# Altium schematic sheet HDMI_CONN_POWER_IN.SchDoc
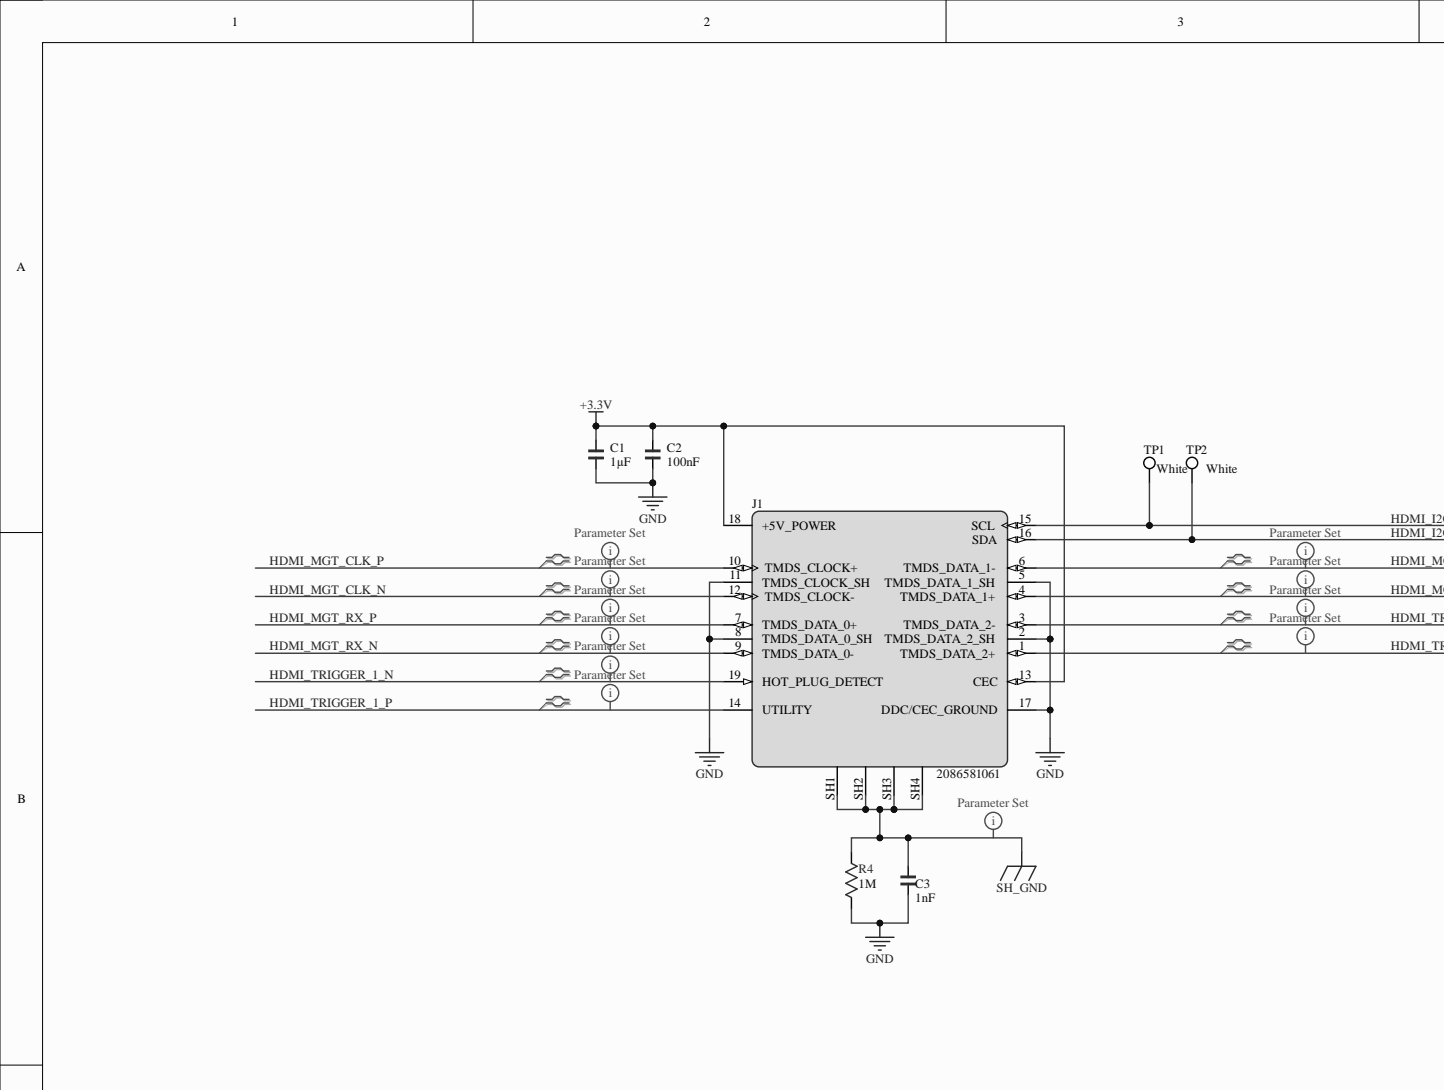
[diagram p0: HDMI_CONN_POWER_IN.SchDoc, top left]
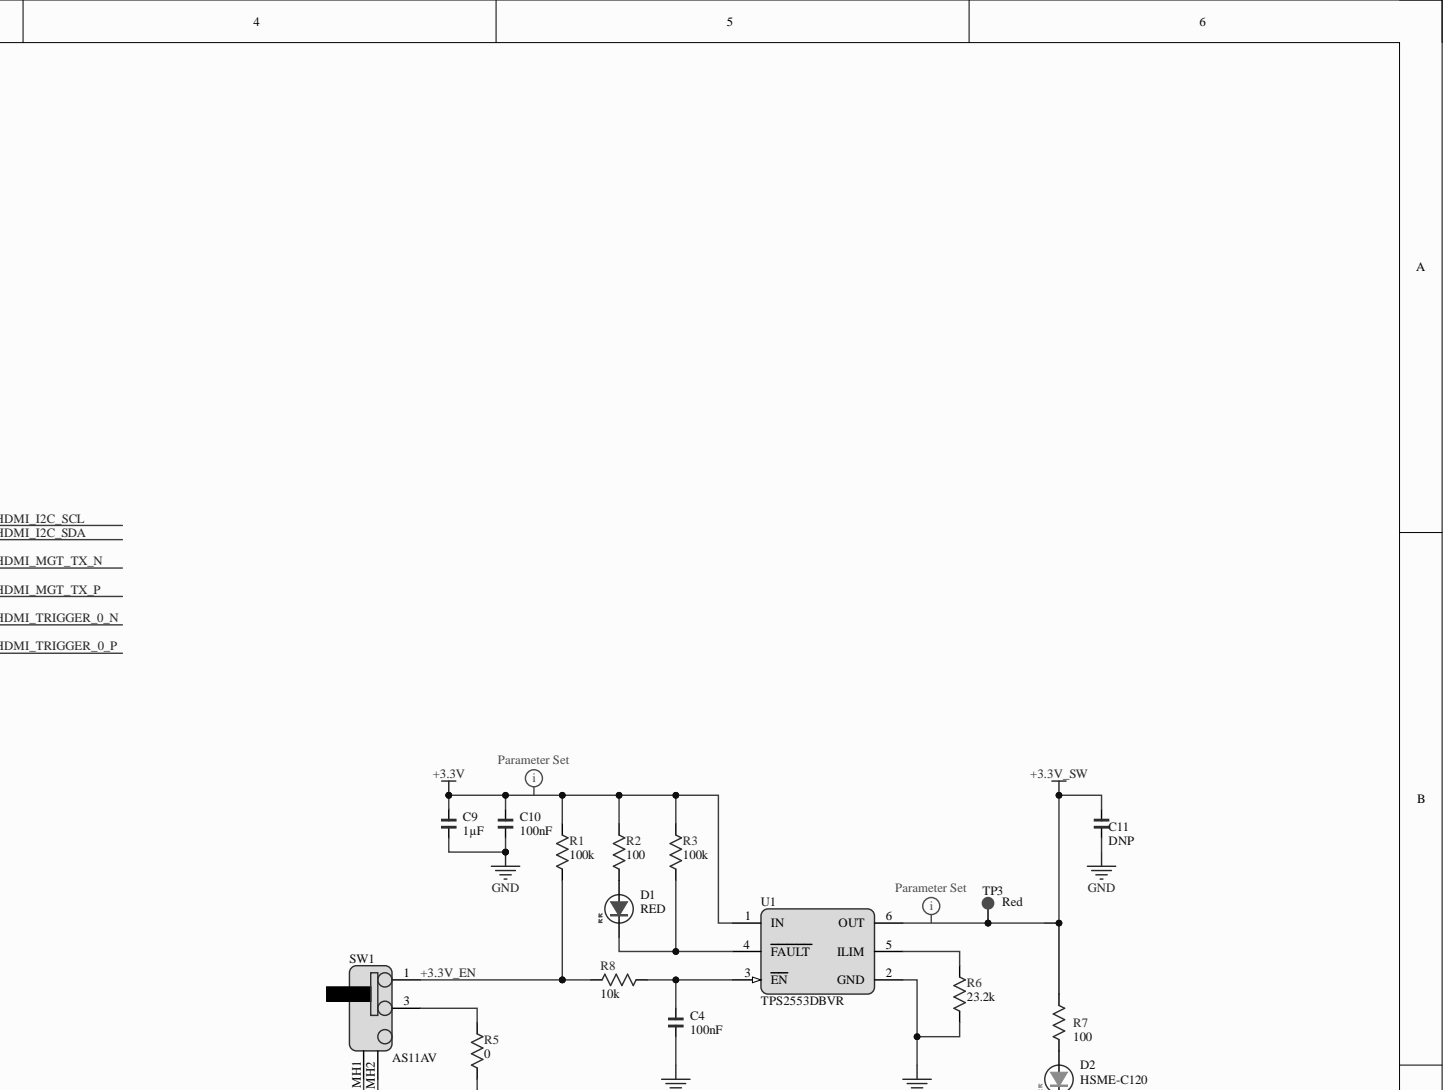
[diagram p1: HDMI_CONN_POWER_IN.SchDoc, top right]
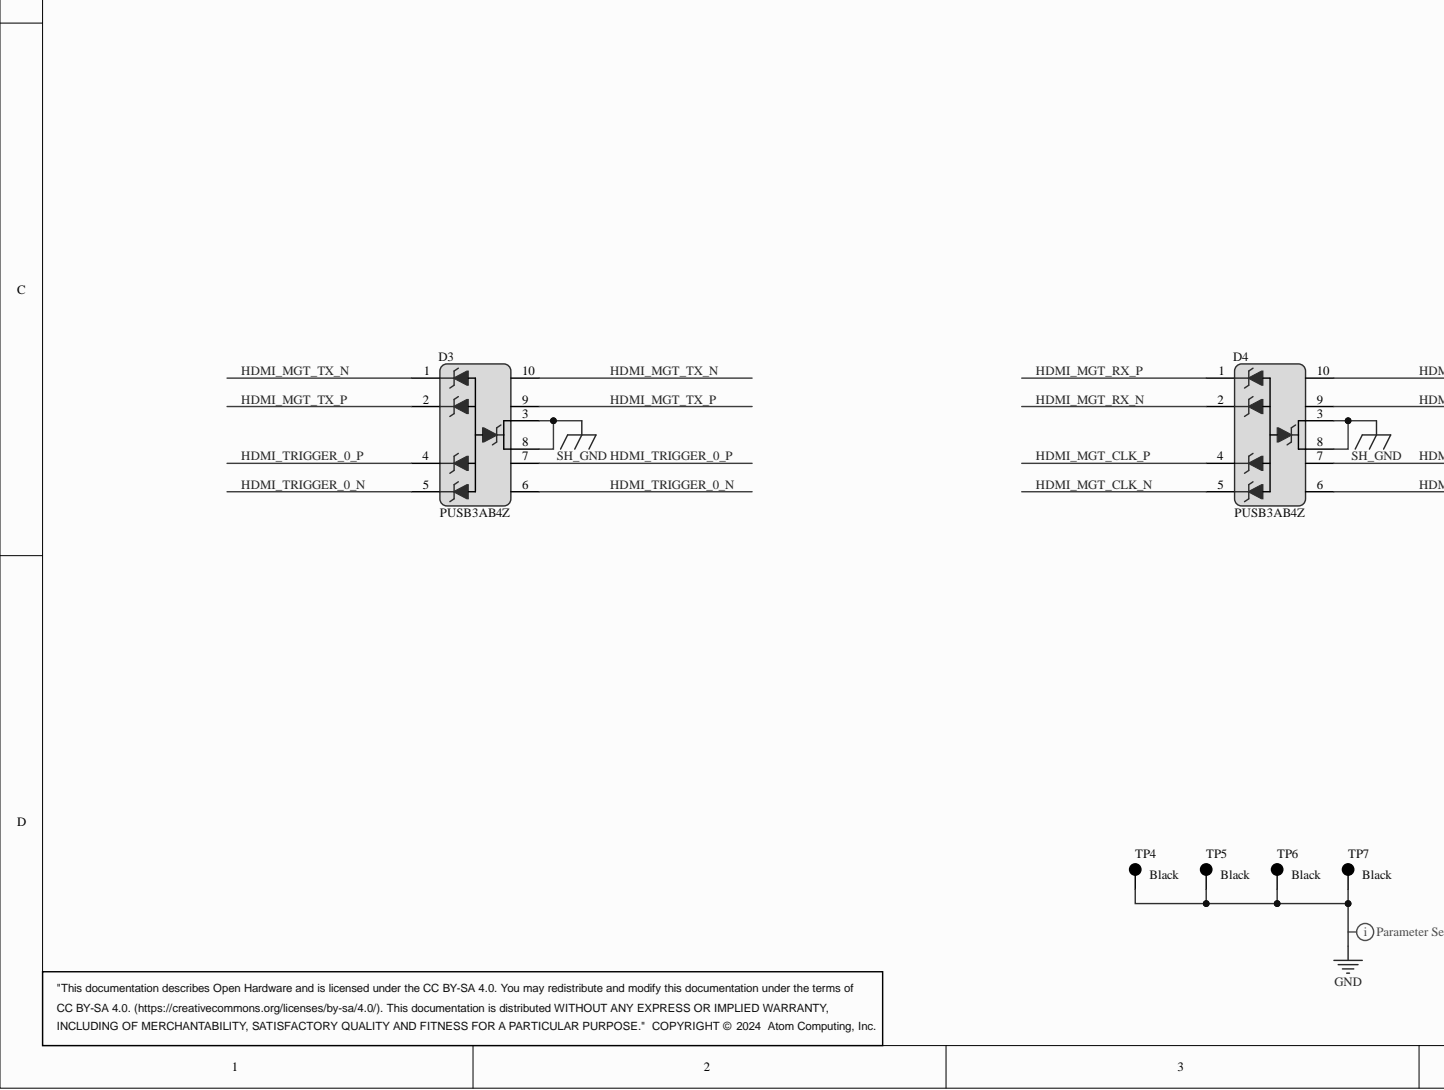
[diagram p2: HDMI_CONN_POWER_IN.SchDoc, bottom left]
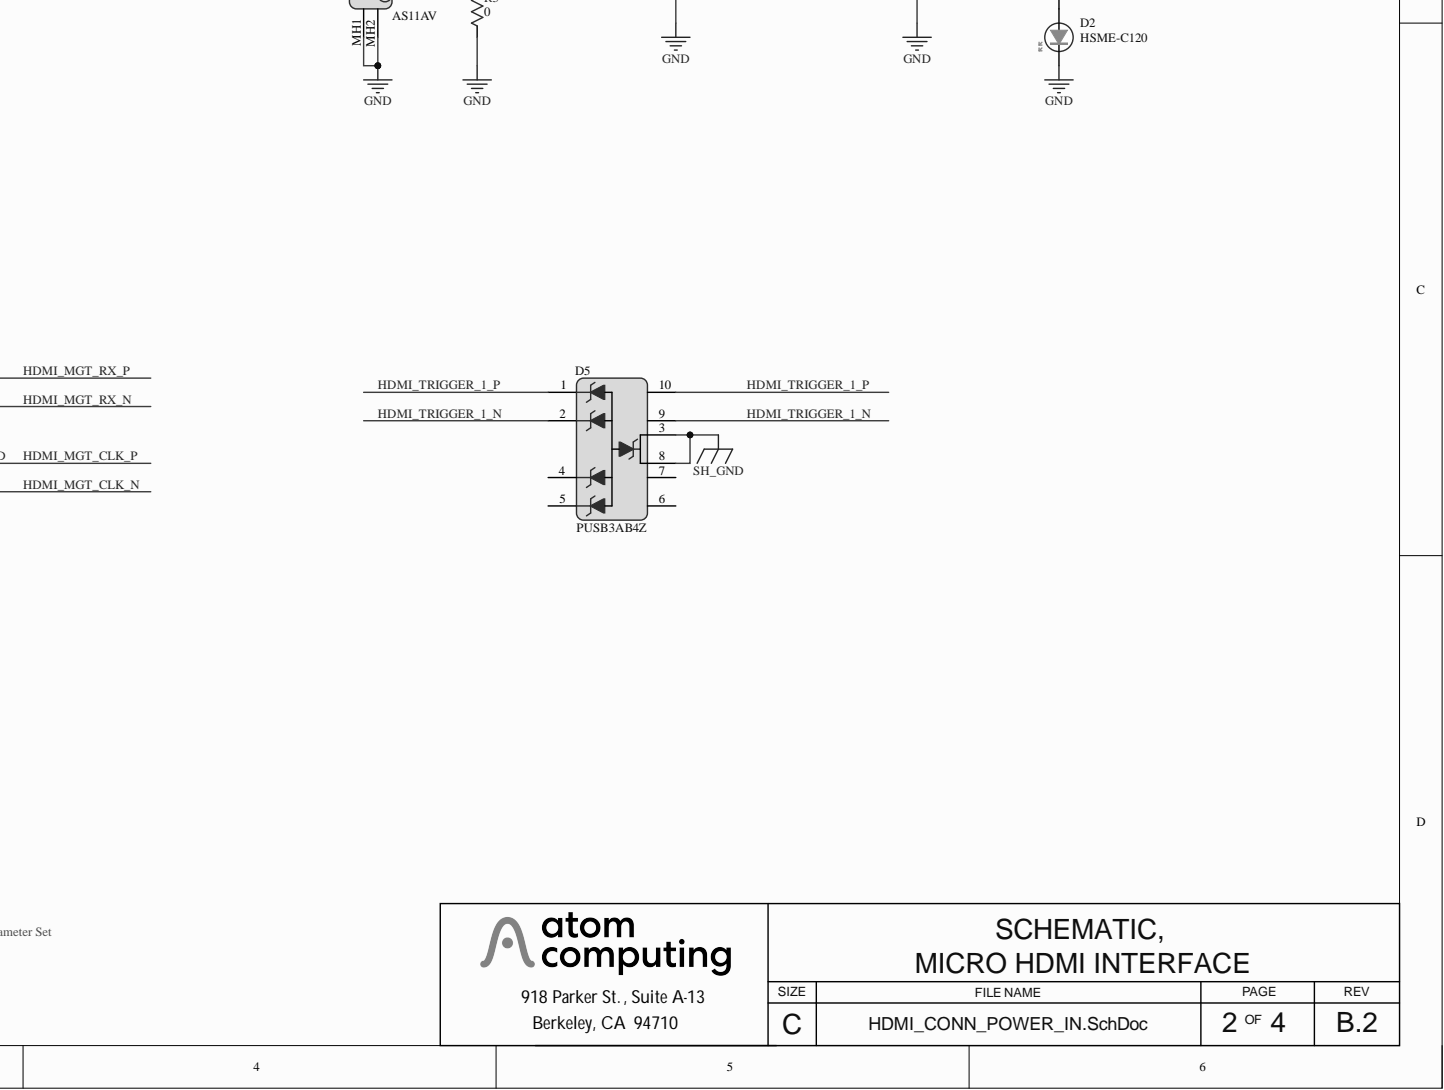
[diagram p3: HDMI_CONN_POWER_IN.SchDoc, bottom right]

## Parts
C1  1µF  [CAPC160X80X95L35]
C2  100nF  [CAPC160X80X95L35]
C3  1nF  [CAPC160X80X95L35]
C4  100nF  [CAPC160X80X95L35]
C9  1µF  [CAPC160X80X95L35]
C10  100nF  [CAPC160X80X95L35]
C11  DNP  [CAPC200X125X145L50]
D1  RED  [LEDSC80X160X55L40X70_C1A2]
D2  HSME-C120  [BROADCOM_HSMX-C120]
D3  PUSB3AB4Z  [NEXPERIA_PUSB3AB4Z]
D4  PUSB3AB4Z  [NEXPERIA_PUSB3AB4Z]
D5  PUSB3AB4Z  [NEXPERIA_PUSB3AB4Z]
J1  2086581061  [MOLEX_2086581061]
R1  100k  [RESC160X85X55L30]
R2  100  [RESC160X85X55L30]
R3  100k  [RESC160X85X55L30]
R4  1M  [RESC320X160X76L51]
R5  0  [RESC160X85X55L30]
R6  23.2k  [RESC160X85X55L30]
R7  100  [RESC160X85X55L30]
R8  10k  [RESC160X85X55L30]
SW1  AS11AV  [AS11AV]
TP1  White  [Keystone_5002]
TP2  White  [Keystone_5002]
TP3  Red  [Keystone_5000]
TP4  Black  [Keystone_5001]
TP5  Black  [Keystone_5001]
TP6  Black  [Keystone_5001]
TP7  Black  [Keystone_5001]
U1  TPS2553DBVR  [SOT23-6P95_280X145L45X37]

## Nets
+3.3V: C1.1 C2.1 C9.1 C10.1 J1.13(CEC) J1.18(+5V_POWER) R1.2 R2.2 R3.2 U1.1(IN)
+3.3V_SW: C11.1 R7.2 TP3.1 U1.6(OUT)
GND: C1.2 C2.2 C3.2 C4.2 C9.2 C10.2 C11.2 D2.1 J1.2(TMDS_DATA_2_SH) J1.5(TMDS_DATA_1_SH) J1.8(TMDS_DATA_0_SH) J1.11(TMDS_CLOCK_SH) J1.17(DDC/CEC_GROUND) R4.1 R5.2 R6.1 SW1.MH1 SW1.MH2 TP4.1 TP5.1 TP6.1 TP7.1 U1.2(GND)
SH_GND: C3.1 D3.3 D3.8 D4.3 D4.8 D5.3 D5.8 J1.SH1 J1.SH2 J1.SH3 J1.SH4 R4.2
+3.3V_EN: R1.1 R8.1 SW1.1
HDMI_I2C_SCL: J1.15(SCL) TP1.1
HDMI_I2C_SDA: J1.16(SDA) TP2.1
HDMI_MGT_CLK_N: D4.5 D4.6 J1.12(TMDS_CLOCK-)
HDMI_MGT_CLK_P: D4.4 D4.7 J1.10(TMDS_CLOCK+)
HDMI_MGT_RX_N: D4.2 D4.9 J1.9(TMDS_DATA_0-)
HDMI_MGT_RX_P: D4.1 D4.10 J1.7(TMDS_DATA_0+)
HDMI_MGT_TX_N: D3.1 D3.10 J1.6(TMDS_DATA_1-)
HDMI_MGT_TX_P: D3.2 D3.9 J1.4(TMDS_DATA_1+)
HDMI_TRIGGER_0_N: D3.5 D3.6 J1.3(TMDS_DATA_2-)
HDMI_TRIGGER_0_P: D3.4 D3.7 J1.1(TMDS_DATA_2+)
HDMI_TRIGGER_1_N: D5.2 D5.9 J1.19(HOT_PLUG_DETECT)
HDMI_TRIGGER_1_P: D5.1 D5.10 J1.14(UTILITY)
NetC4_1: C4.1 R8.2 U1.3(EN)
NetD1_1: D1.1 R3.1 U1.4(FAULT)
NetD1_2: D1.2 R2.1
NetD2_2: D2.2 R7.1
NetR5_1: R5.1 SW1.3
NetR6_2: R6.2 U1.5(ILIM)

Not connected: D5 4 5 6 7

## Library data of the parts
C1 C9 = CMP-001-00135-7: CAP CER 1uF 10V 10% 0603; Mfg: Kemet; Mfg PN: C0603C105K8PACTU; Op Temp: -55°C ~ 85°C; Temp Coeff: X5R; Case/Package: 0603; Component Kind: Standard; Pins: 2; Signal Integrity: Guessed Model; Tolerance: 10%; VALUE: 1µF; Voltage: 10V; Library Name: Atom Computing
C2 C4 C10 = CMP-001-00143-7: CAP CER 0.1UF 25V X7R 0603; Mfg: Kemet; Mfg PN: C0603X104K3RACTU; Tolerance: 10%; Case/Package: 0603; Component Kind: Standard; Pins: 2; Signal Integrity: Guessed Model; Temp Coeff: X7R; VALUE: 100nF; Voltage: 25V; Library Name: Atom Computing
C3 = CMP-001-00209-7: CAP CER 1000PF 50V C0G/NPO 0603; Mfg: Yageo; Mfg PN: CC0603JRNPO9BN102; Temp Coeff: C0G/NP0; Tolerance: 5%; Case/Package: 0603; Component Kind: Standard; Pins: 2; Signal Integrity: Guessed Model; VALUE: 1nF; Voltage: 50V; Library Name: Atom Computing
C11 = CMP-001-00017-8: DNP, CAP 0805; Mfg PN: DNP_CAP_0805; VALUE: 100p; Case/Package: 0805; Component Kind: Standard; Mfg: DNP; Pins: 2; Signal Integrity: Guessed Model; Library Name: Atom Computing
D1 = CMP-004-00024-2: LED RED CLEAR CHIP SMD 629nm, 1.9V, 0603; Current Rating: 30mA; Mfg: Lite-On Inc.; Mfg PN: LTST-C193KRKT-2A; Op Temp: -55°C ~ 85°C; Voltage: 2V; Case/Package: 0603; Component Kind: Standard; Pins: 2; Signal Integrity: Guessed Model; Value: RED; Library Name: Atom Computing
D2 = CMP-004-00020-5: LED GREEN CLEAR CHIP SMD R/A; Forward Voltage: 2.1V; Mfg: AVAGO TECHNOLOGIES; Case/Package: 2-SMD; Component Kind: Standard; Mfg PN: HSME-C120; Mounting Technology: Surface Mount; Nominal Vf: 2.1V; Pins: 2; RoHS Compliant: Yes; Value: GREEN; Library Name: Atom Computing
D3-D5 = CMP-0037-00001-1: TVS DIODE BIDIRECTIONAL 3.3VWM 5VC DFN2510A-10; Current - Peak Pulse: 7A (8/20µs); Mfg: Nexperia USA Inc.; Voltage - Breakdown: 5.5V; Voltage - Clamping: 5V; Voltage - Reverse Standoff: 3.3V; Case/Package: 10-XFDFN; Component Kind: Standard; Max Operating Temperature: 85°C; Mfg PN: PUSB3AB4Z; Min Operating Temperature: -40°C; Mounting Technology: Surface Mount; Pins: 10; RoHS Compliant: Yes; Library Name: Atom Computing
J1 = CMP-002-00061-4: HDMI 2.1 Receptacle Connector 19 Position Surface Mount, Right Angle; Through Hole; Gender: Female; Max Current Rating: 500mA; Mfg: Molex; Voltage Rating: 40V; Case/Package: SMD-RA; Component Kind: Standard; Connector Type: HDMI; Max Operating Temperature: 85°C; Mfg PN: 2086581061; Min Operating Temperature: -20°C; Mounting Technology: Surface Mount/Through Hole; Pins: 23; RoHS Compliant: Yes; Signal Integrity: Guessed Model; Library Name: Atom Computing
R1 R3 = CMP-009-00291-2: RES SMD 100K OHM 1% 1/10W 0603; Mfg: Yageo; Mfg PN: RC0603FR-07100KL; Case/Package: 0603; Component Kind: Standard; Pins: 2; Signal Integrity: Guessed Model; VALUE: 100k; Library Name: Atom Computing
R2 R7 = CMP-009-00467-2: RES SMD 100 OHM 1% 1/10W 0603; Mfg: Panasonic Electronic Components; Mfg PN: ERJ-3EKF1000V; Power: 100mW; Case/Package: 0603; Component Kind: Standard; Max Operating Temperature: 155°C; Min Operating Temperature: -55°C; Mounting Technology: Surface Mount; Pins: 2; RoHS Compliant: Yes; Signal Integrity: Guessed Model; Tolerance: 1%; VALUE: 100; Library Name: Atom Computing
R4 = CMP-009-00313-1: 1 ±1% 0.25W, 1/4W Chip Resistor 1206 (3216 Metric) High Voltage Thick Film; Mfg: Vishay Dale; Mfg PN: RCV12061M00FKEA; Case/Package: 1206; Component Kind: Standard; Pins: 2; Signal Integrity: Guessed Model; VALUE: 1M; Library Name: Atom Computing
R5 = CMP-009-00290-2: RES 0 OHM JUMPER 1/10W 0603; Mfg: Yageo; Mfg PN: RC0603FR-070RL; Case/Package: 0603; Component Kind: Standard; Pins: 2; Signal Integrity: Guessed Model; VALUE: 0; Library Name: Atom Computing
R6 = CMP-009-00553-1: RES SMD 23.2K OHM 1% 1/10W 0603; Mfg: Panasonic Electronic Components; Mfg PN: ERJ-3EKF2322V; Power: 100mW; Case/Package: 0603; Component Kind: Standard; Max Operating Temperature: 155°C; Min Operating Temperature: -55°C; Mounting Technology: Surface Mount; Pins: 2; RoHS Compliant: Yes; Signal Integrity: Guessed Model; Tolerance: 1%; VALUE: 23.2k; Library Name: Atom Computing
R8 = CMP-009-00475-2: RES SMD 10K OHM 1% 1/10W 0603; Mfg: Panasonic Electronic Components; Mfg PN: ERJ-3EKF1002V; Power: 100mW; Case/Package: 0603; Component Kind: Standard; Max Operating Temperature: 155°C; Min Operating Temperature: -55°C; Mounting Technology: Surface Mount; Pins: 2; RoHS Compliant: Yes; Signal Integrity: Guessed Model; Tolerance: 1%; VALUE: 10k; Library Name: Atom Computing
SW1 = CMP-011-00000-3: SWITCH SLIDE SPST 0.4VA 28V Through Hole, Right Angle, Vertical; Current Rating: 100mA; Mfg: NKK Switches; Op Temp: -30°C ~ 85°C; Case/Package: .100" TH-RA; Component Kind: Standard; Mfg PN: AS11AV; Pins: 3; Signal Integrity: Guessed Model; Voltage: 28V; Library Name: Atom Computing
TP1 TP2 = CMP-00030-00002-6: Test Point, White, 1-Pin THD, RoHS, Bulk; Mfg: Keystone; Mfg PN: 5002; Component Kind: Standard; Pins: 1; Value: White; Library Name: Atom Computing
TP3 = CMP-00030-00000-6: Test Point, Red, 1-Pin THD, RoHS, Bulk; Mfg: Keystone; Mfg PN: 5000; Component Kind: Standard; Pins: 1; Value: Red; Library Name: Atom Computing
TP4-TP7 = CMP-00030-00001-5: Test Point, Black, 1-Pin THD, RoHS, Bulk; Mfg: Keystone; Mfg PN: 5001; Component Kind: Standard; Pins: 1; Value: Black; Library Name: Atom Computing
U1 = CMP-035-00004-3: Power Switch/Driver 1:1 N-Channel 1.5A SOT-23-6; Max Supply Voltage: 6.5V; Mfg: Texas Instruments; Min Supply Voltage: 2.5V; Case/Package: SOT-23-6; Component Kind: Standard; Max Operating Temperature: 85°C; Mfg PN: TPS2553DBVR; Min Operating Temperature: -40°C; Mounting Technology: Surface Mount; Output Current: 1.5A; Pins: 6; RoHS Compliant: Yes; Signal Integrity: Guessed Model; Library Name: Atom Computing
